# Altium schematic sheet DemDis.SchDoc(DemDis)
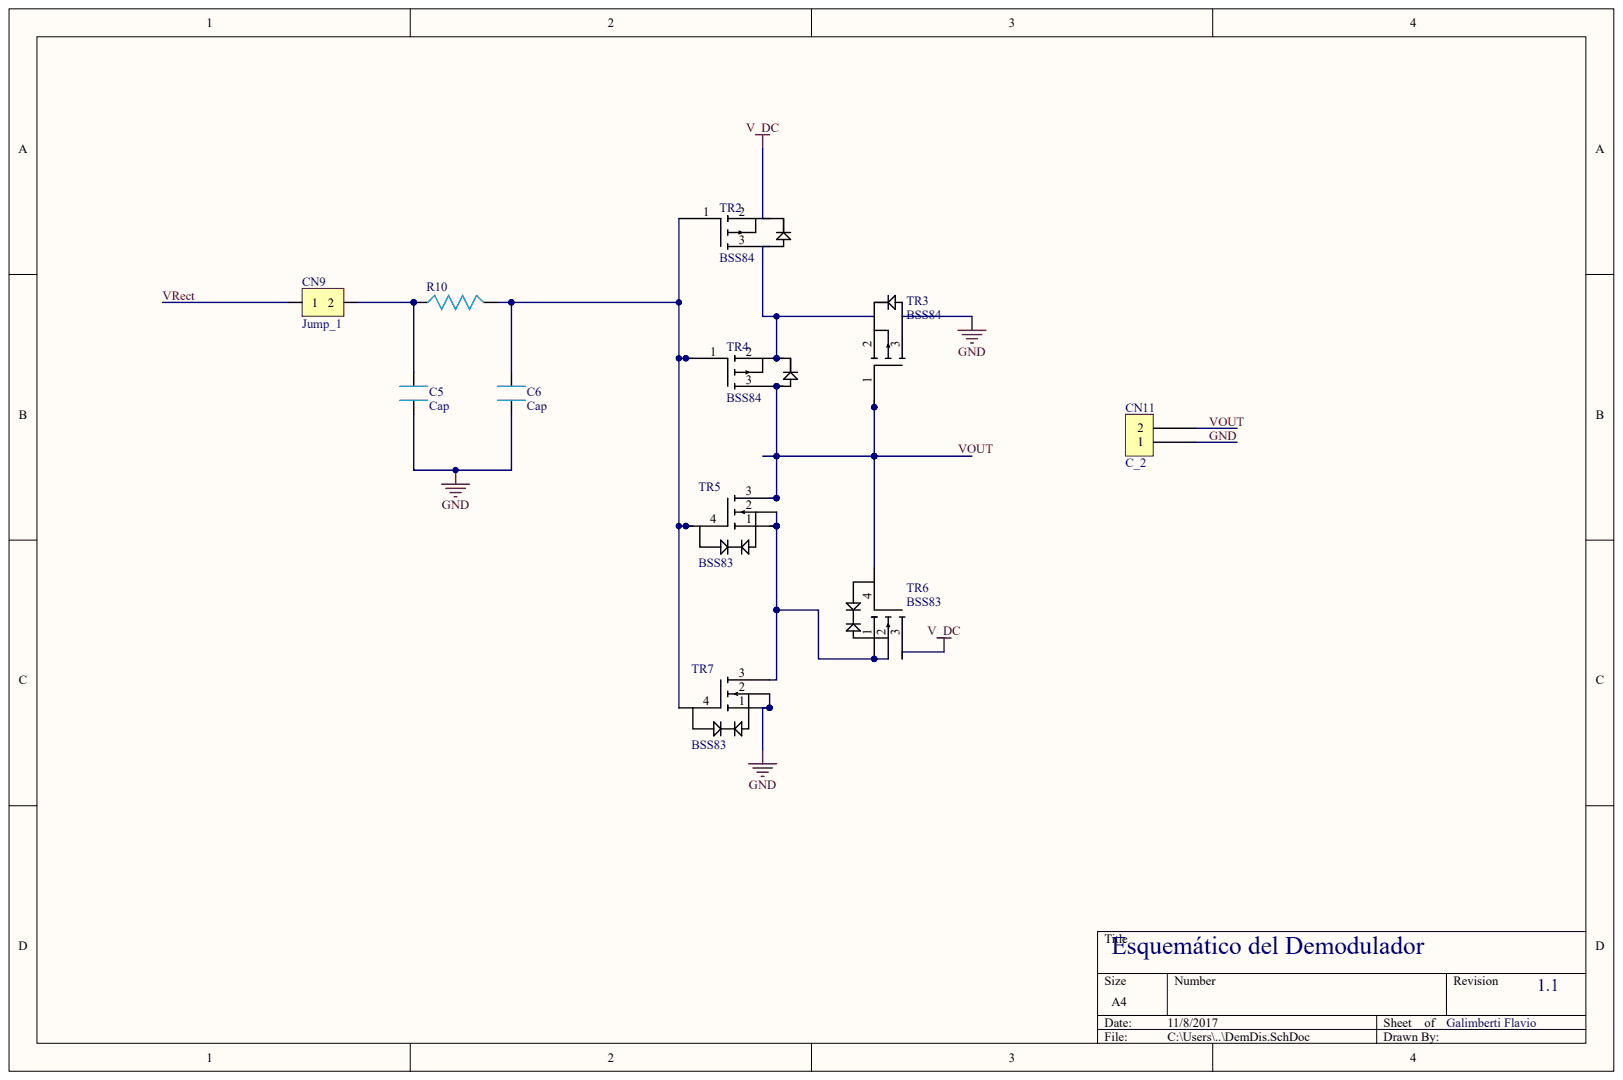
[diagram p0: DemDis.SchDoc]

## Parts
C5  Cap
C6  Cap
CN9  Jump_1
CN11  C_2
R10  R_1/4  (value not drawn)
TR2  BSS84
TR3  BSS84
TR4  BSS84
TR5  BSS83
TR6  BSS83
TR7  BSS83

## Nets
V_DC: TR2.2 TR6.3
GND: C5.2 C6.2 CN11.1 TR3.3 TR7.1 TR7.2
VOUT: CN11.2 TR3.1 TR4.3 TR5.3 TR6.4
VRECT: CN9.1
NetC5_1: C5.1 CN9.2 R10.1
NetC6_1: C6.1 R10.2 TR2.1 TR4.1 TR5.4 TR7.4
NetTR2_3: TR2.3 TR3.2 TR4.2
NetTR5_1: TR5.1 TR5.2 TR6.1 TR6.2 TR7.3
